# Altium schematic sheet dc_motor encoder.SchDoc
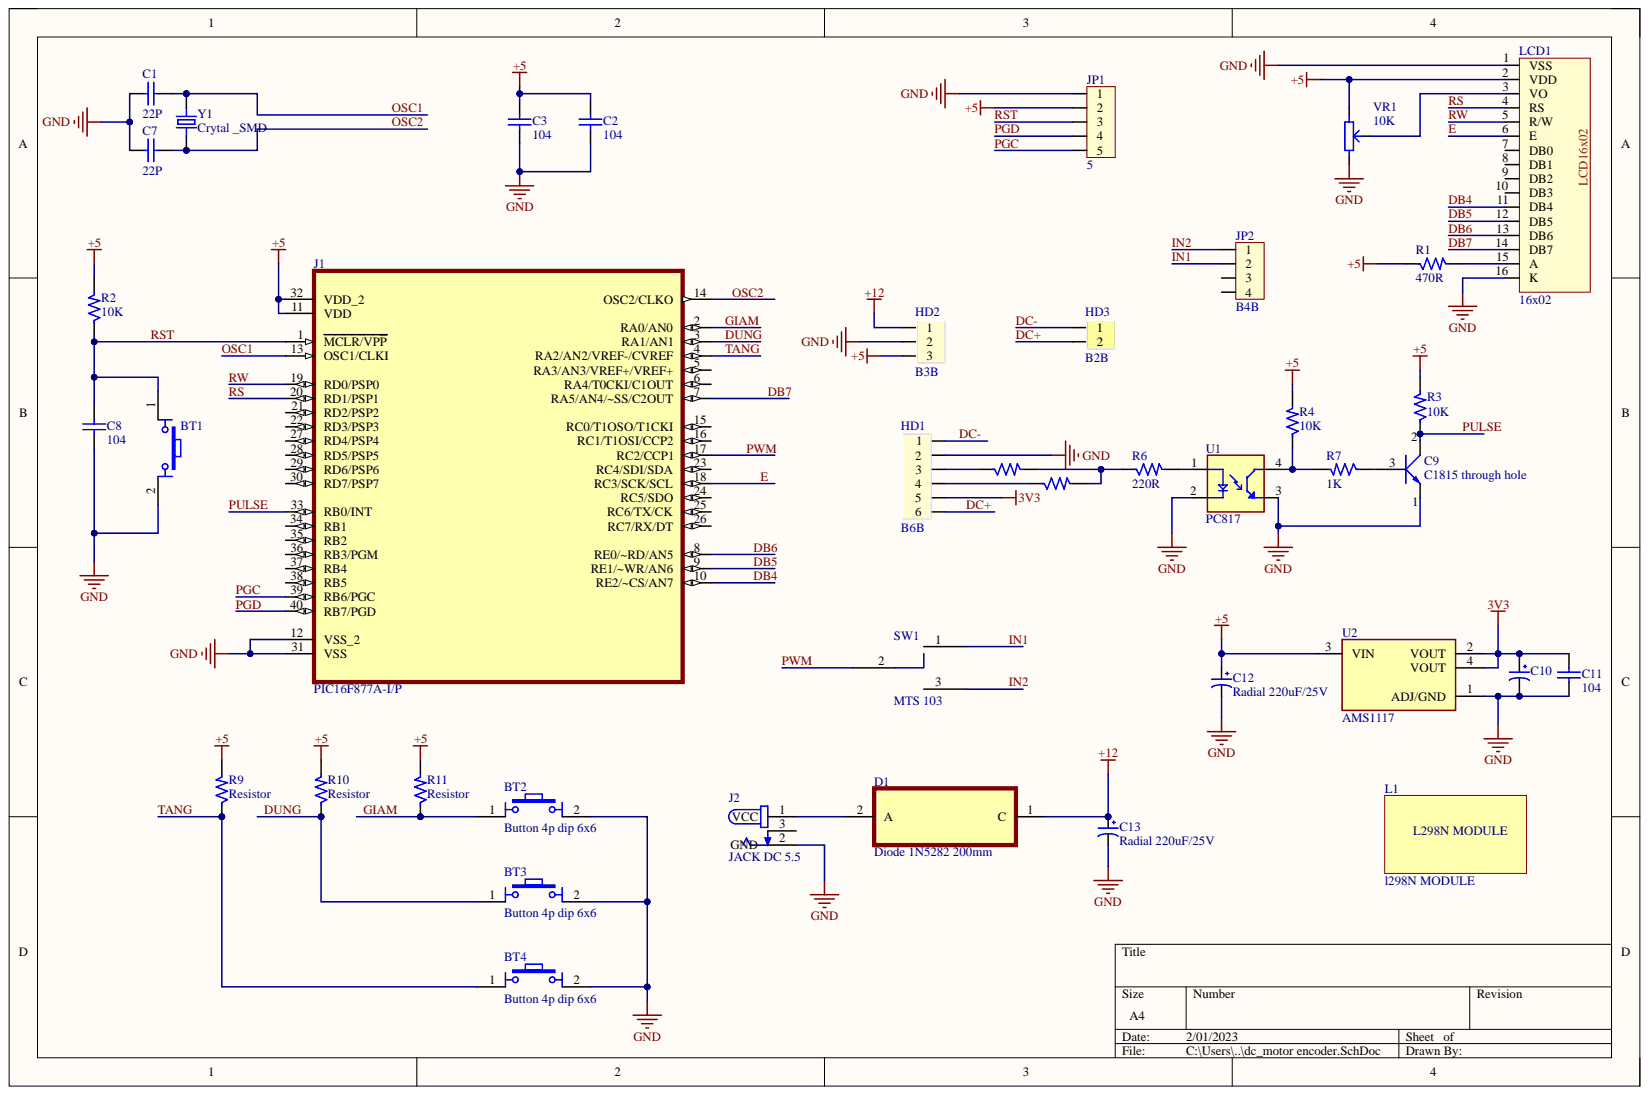
[diagram p0: dc_motor encoder.SchDoc]

## Parts
BT1  Button 4p dip 6x6  [Button 4p 6x6]
BT2  Button 4p dip 6x6  [Button 4p 6x6]
BT3  Button 4p dip 6x6  [Button 4p 6x6]
BT4  Button 4p dip 6x6  [Button 4p 6x6]
C1  22P  [Cap Ceramic 0805]
C2  104  [Cap Ceramic 0805]
C3  104  [Cap Ceramic 0805]
C7  22P  [Cap Ceramic 0805]
C8  104  [Cap Ceramic 0805]
C9  C1815 through hole  [TO92127P478H483-3]
C10  Radial 220uF/25V  [Radial 6.3x12mm]
C11  104  [Cap Ceramic 0805]
C12  Radial 220uF/25V  [Radial 6.3x12mm]
C13  Radial 220uF/25V  [Radial 6.3x12mm]
D1  Diode 1N5282 200mm  MP: 1N5282  [DIO_1N5282 10mm]
HD1  B6B  [Header 6P]
HD2  B3B  [Header 3P]
HD3  B2B  [Header 2P]
J1  PIC16F877A-I/P  [DIP254P1524X483-40]
J2  JACK DC 5.5  [JACK DC]
JP1  5  [JUMP5_T_MALE]
JP2  B4B  [JUMP4_T_MALE]
L1  l298N MODULE  [L298N MODULE]
LCD1  16x02  [LCD16X02_DATA]
R1  470R  [Res_0805]
R2  10K  [Res_0805]
R3  10K  [Res_0805]
R4  10K  [Res_0805]
R5  0R  [Res_0805]  (value not drawn)
R6  220R  [Res_0805]
R7  1K  [Res_0805]
R8  0R  [Res_0805]  (value not drawn)
R9  Resistor  [Res_0805]
R10  Resistor  [Res_0805]
R11  Resistor  [Res_0805]
SW1  MTS 103  [MT 103]
U1  PC817  [PC817 DIP]
U2  AMS1117  [AMS1117]
VR1  10K  [Bien Tro Tam Giac Dung]
Y1  Crytal _SMD  [Crytal_DIP]

## Nets
3V3: C10.1 C11.2 HD1.5 U2.2(VOUT) U2.4(VOUT)
+5: C2.2 C3.2 C12.1 HD2.3 J1.11(VDD) J1.32(VDD_2) JP1.2 LCD1.2(VDD) R1.1 R2.2 R3.2 R4.2 R9.2 R10.2 R11.2 U2.3(VIN) VR1.1
+12: C13.1 D1.1 HD2.1
GND: BT1.2 BT2.2 BT3.2 BT4.2 C1.1 C2.1 C3.1 C7.1 C8.2 C9.1 C10.2 C11.1 C12.2 C13.2 HD1.2 HD2.2 J1.12(VSS_2) J1.31(VSS) J2.2 JP1.1 LCD1.1(VSS) LCD1.16(K) U1.2 U1.3 U2.1(ADJ/GND) VR1.3
DB4: J1.10(RE2/~CS/AN7) LCD1.11(DB4)
DB5: J1.9(RE1/~WR/AN6) LCD1.12(DB5)
DB6: J1.8(RE0/~RD/AN5) LCD1.13(DB6)
DB7: J1.7(RA5/AN4/~SS/C2OUT) LCD1.14(DB7)
DC+: HD1.6 HD3.2
DC-: HD1.1 HD3.1
DUNG: BT3.1 J1.3(RA1/AN1) R10.1
E: J1.18(RC3/SCK/SCL) LCD1.6(E)
GIAM: BT2.1 J1.2(RA0/AN0) R11.1
IN1: JP2.2 SW1.1
IN2: JP2.1 SW1.3
OSC1: C1.2 J1.13(OSC1/CLKI) Y1.2
OSC2: C7.2 J1.14(OSC2/CLKO) Y1.1
PGC: J1.39(RB6/PGC) JP1.5
PGD: J1.40(RB7/PGD) JP1.4
PULSE: C9.2 J1.33(RB0/INT) R3.1
PWM: J1.17(RC2/CCP1) SW1.2
RS: J1.20(RD1/PSP1) LCD1.4(RS)
RST: BT1.1 C8.1 J1.1(MCLR/VPP) JP1.3 R2.1
RW: J1.19(RD0/PSP0) LCD1.5(R/W)
TANG: BT4.1 J1.4(RA2/AN2/VREF-/CVREF) R9.1
NetC9_3: C9.3 R7.1
NetD1_2: D1.2 J2.1
NetHD1_3: HD1.3 R5.1
NetHD1_4: HD1.4 R8.1
NetLCD1_3: LCD1.3(VO) VR1.2
NetLCD1_15: LCD1.15(A) R1.2
NetR4_1: R4.1 R7.2 U1.4
NetR5_2: R5.2 R6.1 R8.2
NetR6_2: R6.2 U1.1

Not connected: J1 5(RA3/AN3/VREF+/VREF+) 6(RA4/T0CKI/C1OUT) 15(RC0/T1OSO/T1CKI) 16(RC1/T1OSI/CCP2) 21(RD2/PSP2) 22(RD3/PSP3) 23(RC4/SDI/SDA) 24(RC5/SDO) 25(RC6/TX/CK) 26(RC7/RX/DT) 27(RD4/PSP4) 28(RD5/PSP5) 29(RD6/PSP6) 30(RD7/PSP7) 34(RB1) 35(RB2) 36(RB3/PGM) 37(RB4) 38(RB5); J2 3; JP2 3 4; LCD1 7(DB0) 8(DB1) 9(DB2) 10(DB3)

## Library data of the parts
BT1-BT4 = Button 4p dip 6x6: Nut Nhan 4 Chan 6x6mm; Ma_Hang: MH004584; Ten: Nút Nhấn 4 Chân 6x6x5MM DIP; Component Kind: Standard; Library Name: KS Chuong.SchLib
C1-C3 C7 C8 C11 = CAP Ceramic SMD: Component Kind: Standard; Library Name: KS Chuong.SchLib
  C2 C3 C8 C11: Description: Cap Ceramic SMD
D1 = Diode 1N5282 200mm: Diode Standard 80V 200mA Through Hole DO-35; MF: ON Semiconductor; Availability: Warning; Component Kind: Standard; Mouser-Purchase-URL: https://snapeda.com/shop?store=Mouser&id=1832060; Package: DO-35 ON Semiconductor; Price: None; SnapEDA_Link: https://www.snapeda.com/parts/1N5282/ON%20Semiconductor/view-part/1832060/?ref=snap; Library Name: KS Chuong.SchLib
HD1 = Header 6P: Value: Connector 6; Component Kind: Standard; Description: Header 6P; Library Name: KS Chuong.SchLib
HD2 = Header 3P: Value: Connector 3; Component Kind: Standard; Description: Header 3P; Library Name: KS Chuong.SchLib
HD3 = Header 2P: Component Kind: Standard; Description: Header 2P; Library Name: KS Chuong.SchLib
J1 = PIC16F877A-I/P: PIC PICÂ® 16F Microcontroller IC 8-Bit 20MHz 14KB (8K x 14) FLASH 44-TQFP (10x10); MF: Microchip; Availability: In Stock; Check_prices: https://www.snapeda.com/parts/PIC16F877A-I/P/Microchip/view-part/49368/?ref=eda; Component Kind: Standard; MP: PIC16F877A-I/P; Package: DIP-40 Atmel; Price: None; SnapEDA_Link: https://www.snapeda.com/parts/PIC16F877A-I/P/Microchip/view-part/49368/?ref=snap; Library Name: KS Chuong.SchLib
J2 = JACK DC 5.5: 2,0 x 6,5 mm, 2,5 A, Yatay, A莽谋k Delik, Dc G眉莽 Jak谋 Konnekt枚r眉; MF: CUI Devices; MP: PJ-002A; Availability: Unavailable; Component Kind: Standard; CUI_purchase_URL: https://www.cuidevices.com/product/interconnect/connectors/dc-power-connectors/jacks/pj-002a?utm_source=snapeda.com&utm_medium=referral&utm_campaign=snapedaBOM; Package: None; Price: None; SnapEDA_Link: https://www.snapeda.com/parts/PJ-002A/CUI%20Devices/view-part/287588/?ref=snap; Library Name: KS Chuong.SchLib
JP1 = JUMP 1x5: JUMP5x1; Value: Connector 4; Component Kind: Standard; Library Name: KS Chuong.SchLib
JP2 = JUMP 1x4: JUMP4x1; Value: Connector 4; Component Kind: Standard; Library Name: KS Chuong.SchLib
LCD1 = LCD16x2: LCd16x02; Value: Connector 8; Component Kind: Standard; Library Name: KS Chuong.SchLib
R1-R11 = Res SMD: Component Kind: Standard; Library Name: KS Chuong.SchLib
U1 = PC817: Optoisolator Transistor Output 5000Vrms 1 Channel 4-DIP; MF: SHARP/Socle Technology; MP: PC817X1CSZ9F; Availability: In Stock; Check_prices: https://www.snapeda.com/parts/PC817X1CSZ9F/SHARP%252FSocle+Technology/view-part/?ref=eda; Component Kind: Standard; Package: DIP-4 SHARP/Socle Technology; Price: None; SnapEDA_Link: https://www.snapeda.com/parts/PC817X1CSZ9F/SHARP%252FSocle+Technology/view-part/?ref=snap; Library Name: KS Chuong.SchLib
U2 = AMS1117: Sot223/Pkg 1-Amp 5-Volt Low Drop Out Voltage Regulatator; Availability: Unavailable; Component Kind: Standard; MP: AMS1117; Package: None; Price: None; Library Name: KS Chuong.SchLib
VR1 = Potentiometer triangle lie: Cắm; Don_Vi: Con; Ma_Hang: MH000919; Ten_Linh_Kien: Biến Trở Tam Giác 103-10K RM065 (Chân Đứng); Chung_Loai: Cắm; Component Kind: Standard; Library Name: KS Chuong.SchLib
Y1 = Crytal: 20MHz  Crystal Series 30 Ohms HC-49/US; MF: ECS Inc.; MP: ECS-200-S-5PX-TR; Availability: Unavailable; Component Kind: Standard; Package: HC49/US ECS International; Price: None; SnapEDA_Link: https://www.snapeda.com/parts/ECS-200-S-5PX-TR/ECS%20Inc./view-part/2459090/?ref=snap; Library Name: KS Chuong.SchLib
C9 = C1815 through hole: Component Kind: Standard; Library Name: KS Chuong.SchLib
C10 C12 C13 = Radial 220uF/25V: Component Kind: Standard; Description: Radial 220uF/25V; Library Name: KS Chuong.SchLib
L1 = l298N MODULE: Component Kind: Standard; Library Name: KS Chuong.SchLib
SW1 = MTS 103: Component Kind: Standard; Library Name: KS Chuong.SchLib
